# Altium schematic sheet downConverter.SchDoc
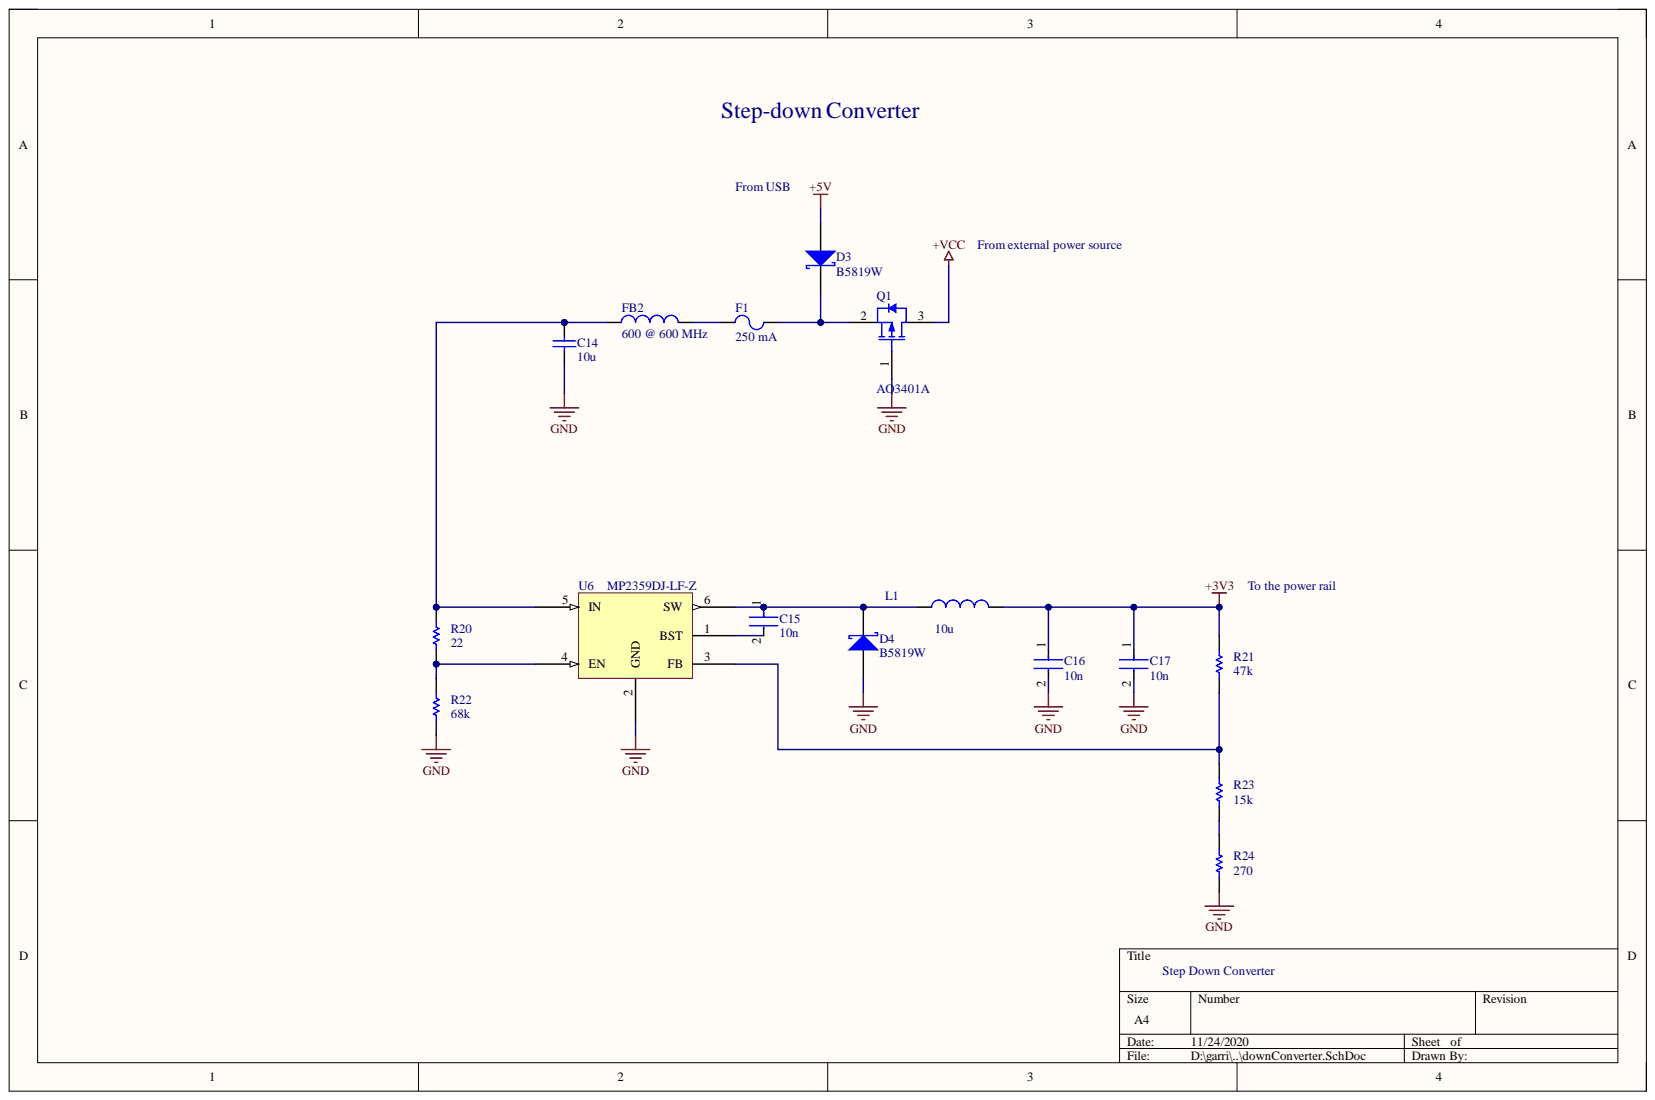
[diagram p0: downConverter.SchDoc]

## Parts
C14  10u  [Capacitor 1206]
C15  10n  [Capacitor 0402]
C16  10n  [Capacitor 0402]
C17  10n  [Capacitor 0402]
D3  B5819W  [SOD3716X135N]
D4  B5819W  [SOD3716X135N]
F1  250 mA  [Fuse 1206]
FB2  600 @ 600 MHz  [Inductor 0805]
L1  10u  [LQH32DN100K23L]
Q1  AO3401A  [SOT95P245X110-3N]
R20  22  [RESISTOR 0603]
R21  47k  [RESISTOR 0603]
R22  68k  [RESISTOR 0603]
R23  15k  [RESISTOR 0603]
R24  270  [RESISTOR 0603]
U6  MP2359DJ-LF-Z  [SOT95P280X100-6N]

## Nets
+3V3: C16.1 C17.1 L1.2 R21.2
+5V: D3.1
+VCC: Q1.3
GND: C14.1 C16.2 C17.2 D4.1 Q1.1 R22.1 R24.1 U6.2(GND)
NetC14_2: C14.2 FB2.1 R20.2 U6.5(IN)
NetC15_1: C15.1 D4.2 L1.1 U6.6(SW)
NetC15_2: C15.2 U6.1(BST)
NetD3_2: D3.2 F1.2 Q1.2
NetF1_1: F1.1 FB2.2
NetR20_1: R20.1 R22.2 U6.4(EN)
NetR21_1: R21.1 R23.2 U6.3(FB)
NetR23_1: R23.1 R24.2

## Library data of the parts
C14 = Capacitor 1206: Chip Capacitor, 1206; Manufacturer: Murata; Manufacturer Part Number: GRM1885C1H220JA01D; Capacitance: 22pF; Dielectric: C0G; Rated Voltage: 50V; Tolerance (%): ±5%; Case Size/Dimension: 0603; Component Kind: Standard; Datasheet URL: https://datasheet.ciiva.com/11786/0900766b807a1cab-11786398.pdf; Datasheet Version: Jul-06; Library Name: F4_Flight_Controller.SCHLIB; Manufacturer URL: http://www.murata.com/; Package Description: 2-Pin Surface Mount Device, Body 1.6 x 0.8 mm; Package Reference: 603
C15-C17 = Capacitor 0402: Chip Capacitor 0402; Manufacturer: Yageo; Manufacturer Part Number: CC0402KRX7R7BB103; Automotive Grade: NA; Capacitance: 10 nF; Material: Ceramic; Temperature Characteristic: X7R; Temperature Coefficient: 30ppm/°C; Tolerance: 0.1; Value: 10 nF; Voltage Rating: 16 V; Automotive: No; Category: Cap; Component Kind: Standard; ComponentLink2Description: Datasheet; ComponentLink2URL: http://www.yageo.com/documents/recent/UPY-GP_NP0_16V-to-50V_16.pdf; Device Class L1: Passive Components; Device Class L2: Capacitors; Device Class L3: Ceramic Capacitors; Digikey Description: CAP CER 10000PF 16V X7R 0402; Footprint Url: http://www.yageo.com/exep/pages/download/literatures/UPY-C_GEN_24.pdf; Height: 0.55mm; Ipc Land Pattern Name: CAPC10050X50; Lead Free: Yes; Library Name: F4_Flight_Controller.SCHLIB; Mouser Description: 0402 10 nF 16 V ±10 % Tolerance X7R SMT Multilayer Ceramic Capacitor; Octopart: https://octopart.com/cc0402krx7r7bb103-yageo-192895; Package: CC0402; RoHS: TRUE; Temperature: -55°C to +125°C; Temperature Range High: +125°C; Temperature Range Low: -55°C
D3 D4 = Schottky Barrier Rectifier: Schottky Barrier Rectifier, 20 V, 1 A, -65 to 150 degC, 2-Pin DO-214AC (SMA), RoHS, Tape and Reel; Manufacturer: Vishay Intertechnology; Manufacturer Part Number: SS12-E3\61T; Forward Current: 1A; Forward Voltage: 500mV; Max Surge Current: 40A; Peak Reverse Current: 6mA; Case/Package: SMA; Component Kind: Standard; Component Type: Diodes; Datasheet URL: http://www.vishay.com/docs/88746/ss12.pdf; Datasheet Version: Aug-15; Library Name: F4_Flight_Controller.SCHLIB; Manufacturer URL: http://www.vishay.com/; Max Operating Temperature: 125°C; Min Operating Temperature: -65°C; Mounting Technology: SM; Package Description: 2-Pin Surface Mount Device, Body 4.245 x 2.665 mm; Package Quantity: 1800; Package Reference: DO-214AC (SMA); Packaging: TapeandReel; Pins: 2; RoHS Compliant: True
F1 = Fuse 1206: NANO2(R) Slo-Blo(R) Fuse, 0.5 A, 125 V, -55 to 125 degC, 2-Pin SMD, RoHS, Tape and Reel; Manufacturer: Littelfuse; Manufacturer Part Number: 0452.500MRL; Current Rating: 0.5A; Working Voltage-Max: 125V; Component Kind: Standard; Datasheet URL: http://download.siliconexpert.com/pdfs/2015/7/2/10/39/10/885/ltf_/manual/4322647674859060843226474844920160littelfuse_fuse_452_454_datasheet.pdf.pdf.pdf; Datasheet Version: 07/2015; Library Name: F4_Flight_Controller.SCHLIB; Manufacturer URL: http://www.littelfuse.com/; Mounting Technology: SurfaceMount; Operating Temp Range: -55to+125°C; Package Description: 2-Pin Surface Mount Device, Body 6.1 x 2.69 mm; Package Reference: 0452; Response Characteristic: Slo-Blo; Size: 6.10x2.69mm
FB2 = Ferrite Bead: Ferrite Bead Murata BLM21AG601SN1D; Manufacturer: TDK; Manufacturer Part Number: MLZ2012A1R0MT000; DC Resistance: 0.6; Inductance-Nom: 10H; Rated Current-Max: 100mA; Tolerance (%): 20%; Case Size/Dimension: 0805; Component Kind: Standard; Datasheet URL: http://psearch.en.murata.com/inductor/product/LQM21FN100M70%23.pdf; Datasheet Version: 05/2016; Library Name: F4_Flight_Controller.SCHLIB; Manufacturer URL: http://www.murata.com/; Mounting Technology: SurfaceMount; Package Description: 2-Pin Surface Mount Device, Body 2 x 1.25 mm; Package Reference: IND0805
L1 = Fixed Inductor: LQH32CN100K33L 10uh; Manufacturer: TDK; Manufacturer Part Number: MLZ2012A1R0MT000; DC Resistance: 0.6; Inductance-Nom: 10H; Rated Current-Max: 100mA; Tolerance (%): 20%; Case Size/Dimension: 0805; Component Kind: Standard; Datasheet URL: http://psearch.en.murata.com/inductor/product/LQM21FN100M70%23.pdf; Datasheet Version: 05/2016; Library Name: F4_Flight_Controller.SCHLIB; Manufacturer URL: http://www.murata.com/; Mounting Technology: SurfaceMount; Package Description: 2-Pin Surface Mount Device, Body 2 x 1.25 mm; Package Reference: IND0805
Q1 = P-Channel MOSFET: P-Channel MOSFET, 30 V, 4 A, -55 to 150 degC, 3-Pin SOT-23-3L, RoHS, Tape and Reel; Manufacturer: Alpha & Omega Semiconductor; Channel Mode: Enhancement; Continuous Drain Current: 4A; Drain Efficiency: NotRequired%; Drain-Source On-Res: 0.044ohm; Drain-Source On-Volt: 30V; Frequency (Max): NotRequiredMHz; Gate-Source Voltage (Max): ?12V; Noise Figure: NotRequireddB; Number of  Elements: 1; Output Power (Max): NotRequiredW; Polarity: P; Power Dissipation: 1.4W; Rad Hardened: No; Type: PowerMOSFET; Component Kind: Standard; Datasheet URL: http://www.aosmd.com/res/data_sheets/AO3401A.pdf; Datasheet Version: Rev. 3, 03/2011; Drain Current (Max): 4A; Library Name: F4_Flight_Controller.SCHLIB; Manufacturer Part Number: AO3401A; Manufacturer URL: http://www.aosmd.com/; Mounting: SurfaceMount; Mounting Technology: SurfaceMount; Operating Temp Range: -55Cto150C; Operating Temperature Classification: Military; Package Description: 3-Pin Small Outline Transistor, Body 2.95 x 1.6 mm, Pitch 0.95 mm; Package Reference: SOT-23-3L; Package Type: SOT-23; Package URL: http://www.aosmd.com/res/packaging_information/SOT-23-3L.pdf; Pin Count: 3; Power Gain: NotRequireddB
R20-R24 = Resistor 0603: Chip Resistor 0603; Manufacturer: Vishay; Manufacturer Part Number: CRCW0402120RFKED; Component Kind: Standard; Library Name: F4_Flight_Controller.SCHLIB; Part Number: CRCW0402120RFKED
U6 = MP2359DJ-LF-Z: Regulator; Component Kind: Standard; Library Name: F4_Flight_Controller.SCHLIB
